# Altium schematic sheet ADC.SchDoc(ADC)
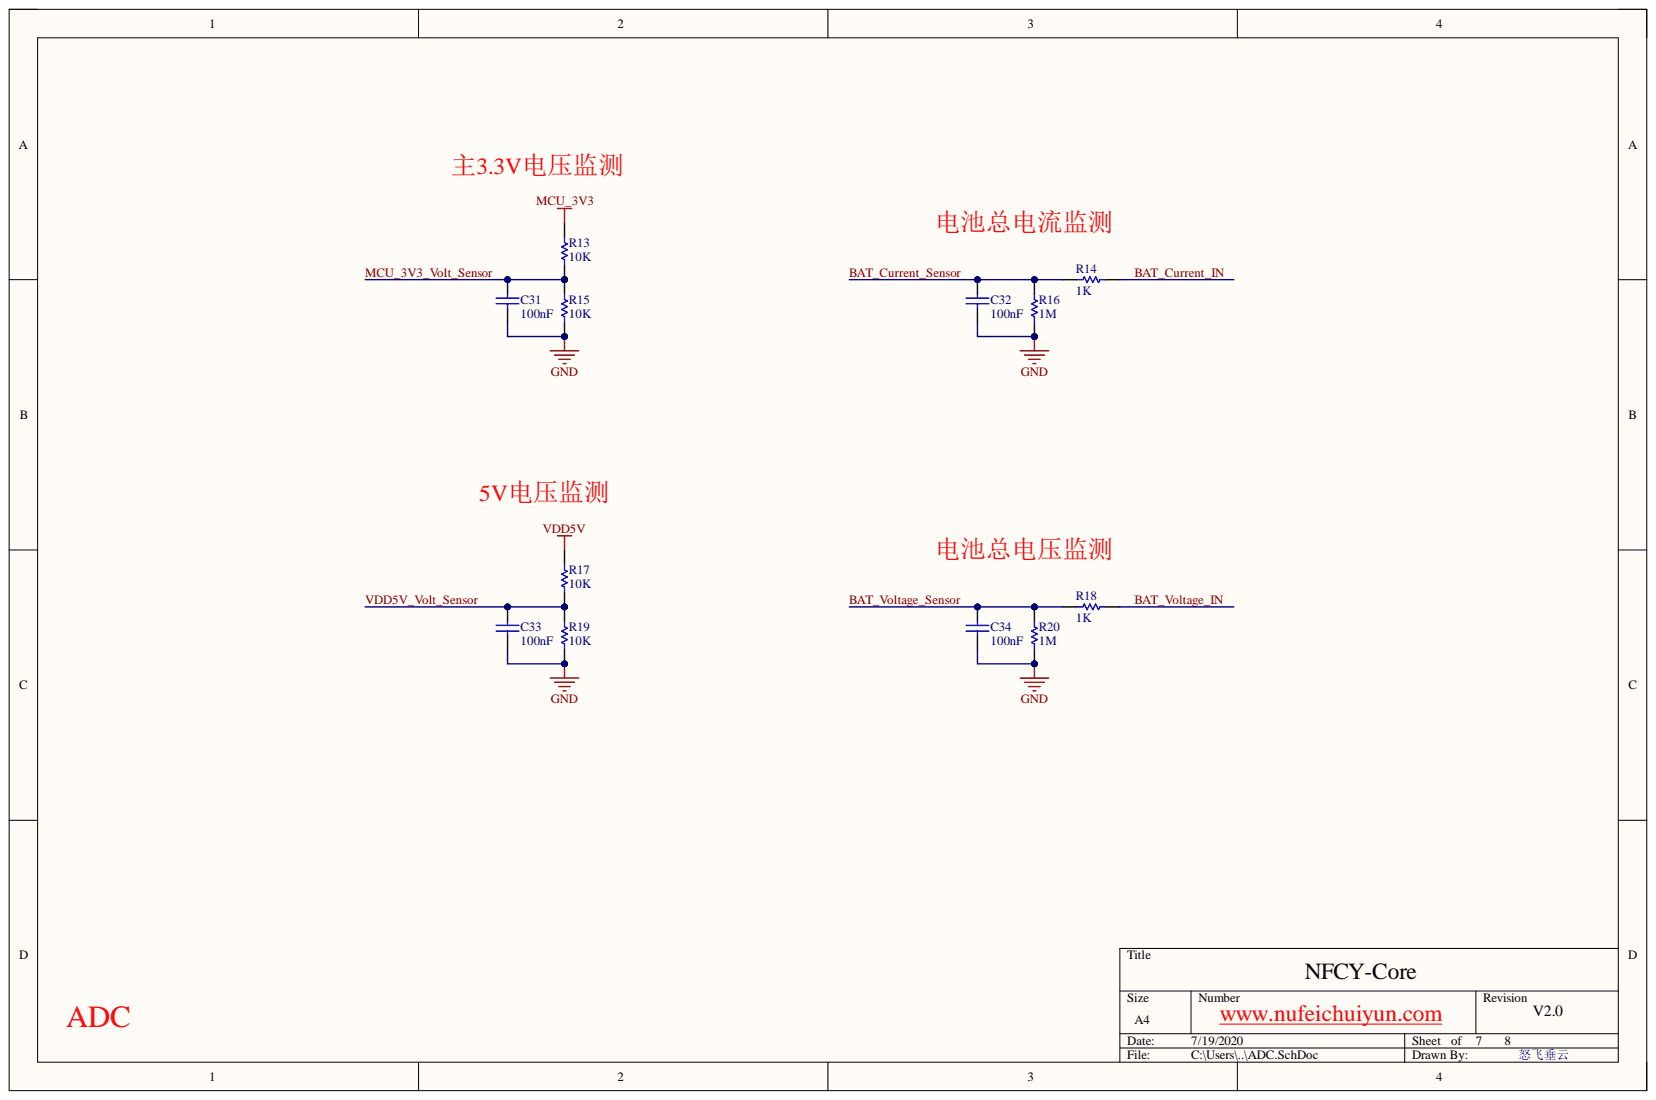
[diagram p0: ADC.SchDoc]

## Parts
C31  value 100nF  [CAP0402]  (hidden comment: CL05B104KO5NNNC)
C32  value 100nF  [CAP0402]  (hidden comment: CL05B104KO5NNNC)
C33  value 100nF  [CAP0402]  (hidden comment: CL05B104KO5NNNC)
C34  value 100nF  [CAP0402]  (hidden comment: CL05B104KO5NNNC)
R13  value 10K  [RES0402]  (hidden comment: RC0402FR-0710KL)
R14  value 1K  [RES0402]  (hidden comment: RC0402FR-071KL)
R15  value 10K  [RES0402]  (hidden comment: RC0402FR-0710KL)
R16  value 1M  [RES0402]  (hidden comment: RC0402FR-071ML)
R17  value 10K  [RES0402]  (hidden comment: RC0402FR-0710KL)
R18  value 1K  [RES0402]  (hidden comment: RC0402FR-071KL)
R19  value 10K  [RES0402]  (hidden comment: RC0402FR-0710KL)
R20  value 1M  [RES0402]  (hidden comment: RC0402FR-071ML)

## Nets
GND: C31.2 C32.2 C33.2 C34.2 R15.1 R16.2 R19.1 R20.2
MCU_3V3: R13.2
VDD5V: R17.2
BAT_Current_IN: R14.2
BAT_Current_Sensor: C32.1 R14.1 R16.1
BAT_Voltage_IN: R18.2
BAT_Voltage_Sensor: C34.1 R18.1 R20.1
MCU_3V3_Volt_Sensor: C31.1 R13.1 R15.2
VDD5V_Volt_Sensor: C33.1 R17.1 R19.2

## Library data of the parts
C31-C34 = Cap: 贴片电容; manufacturer: SAMSUNG(三星); Component Kind: Standard; LatestRevisionDate: 17-Jul-2002; LatestRevisionNote: Re-released for DXP Platform.; Library Name: Miscellaneous Devices.IntLib; PackageDescription: Radial Cap, Thru-Hole; 2 Leads; 0.3 in Pin Spacing; PackageReference: RAD-0.3; Published: 8-Jun-2000; Signal Integrity: Cap; Simulation: CAP; Publisher: Altium Limited
R13-R20 = Res1: 贴片电阻; manufacturer: YAGEO(国巨); Component Kind: Standard; LatestRevisionDate: 17-Jul-2002; LatestRevisionNote: Re-released for DXP Platform.; Library Name: Miscellaneous Devices.IntLib; PackageDescription: Axial Device, Thru-Hole; 2 Leads; 0.3 in Pin Spacing; PackageReference: AXIAL-0.3; Published: 8-Jun-2000; Signal Integrity: Res; Simulation: RESISTOR; Publisher: Altium Limited
